# Altium schematic sheet Main.SchDoc
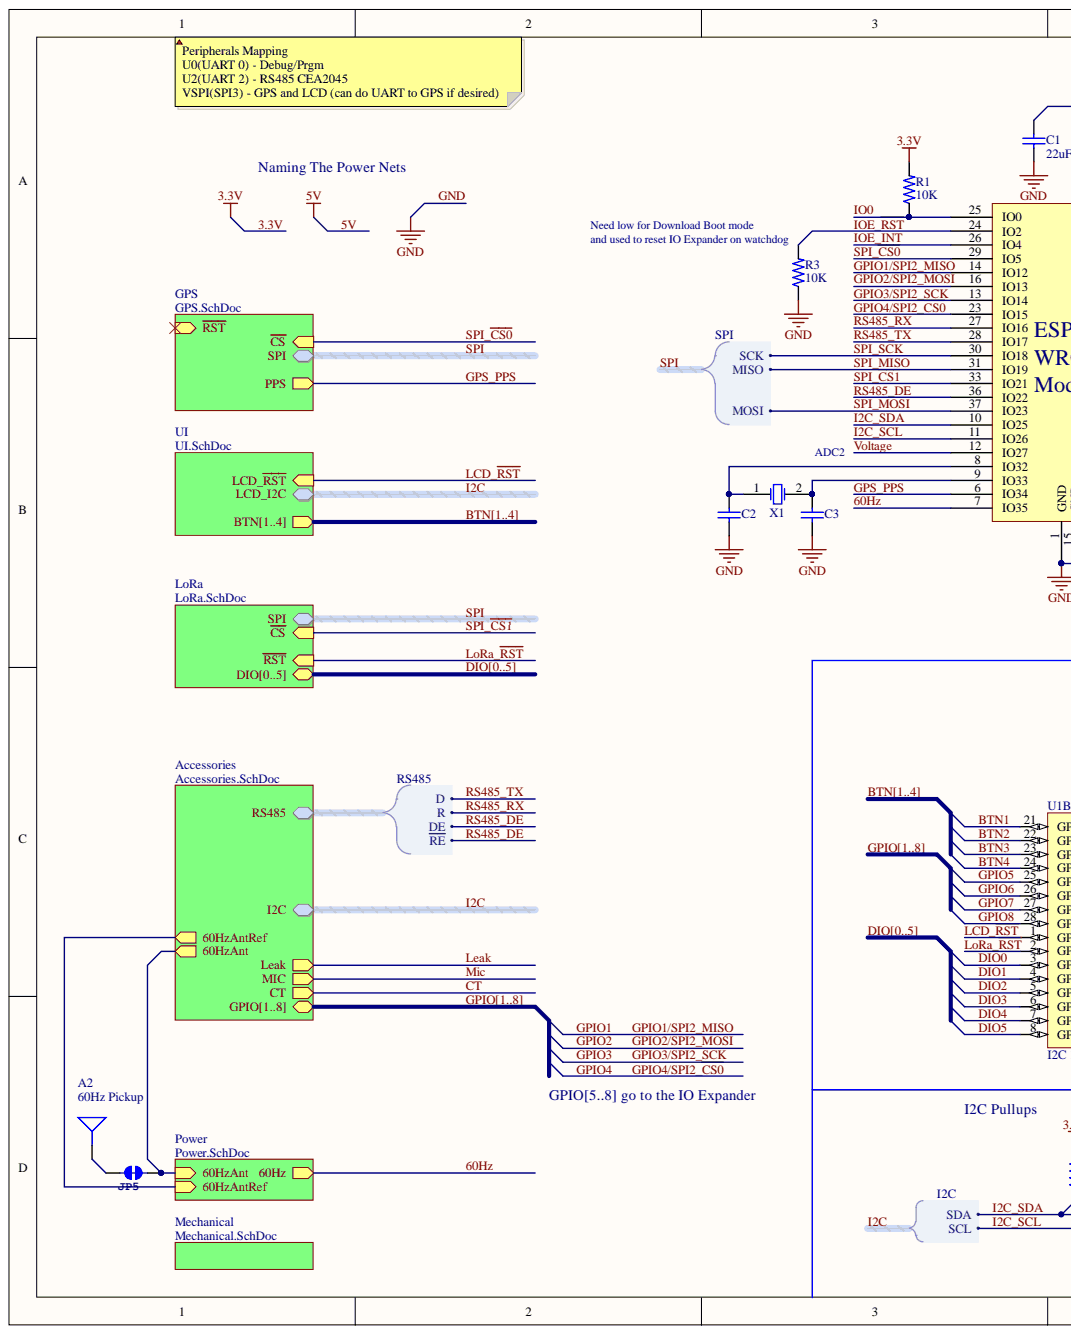
[diagram p0: Main.SchDoc, left]
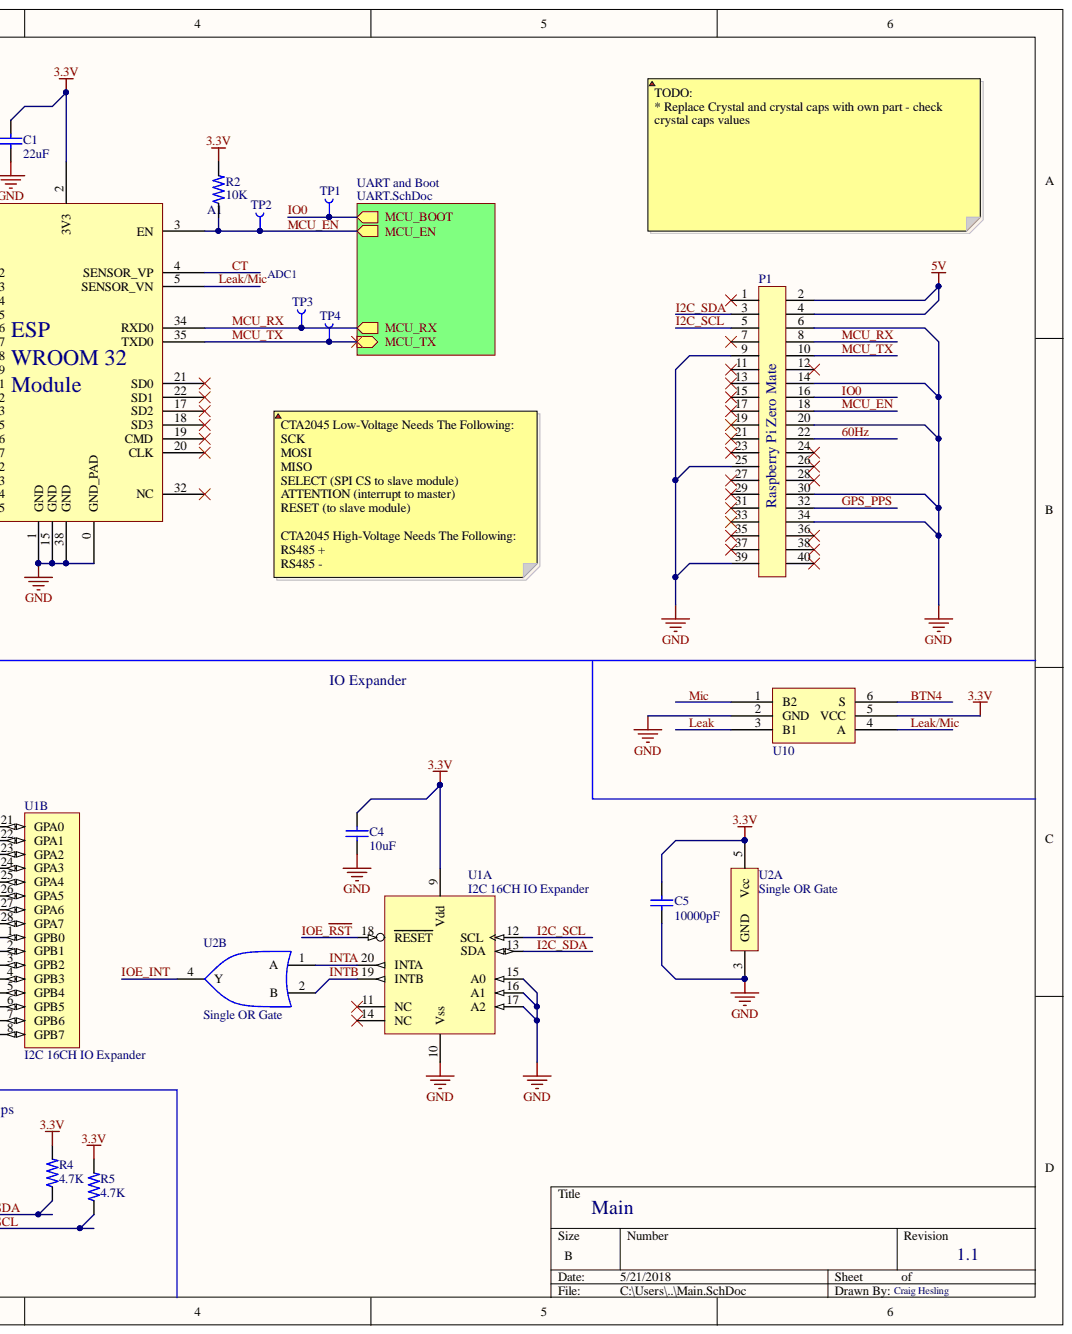
[diagram p1: Main.SchDoc, right]

## Parts
A1  ESP-WROOM-32  [ESP-WROOM-32]  (value not drawn)
A2  60Hz Pickup  [60HzPickup]
C1  22uF  [CAPC2013X14L - CAP0805 1.45mm Tall]
C2  CAP 12pF 16V 0603(1608)  [CAPC0603(1608)100_M]  (value not drawn)
C3  CAP 12pF 16V 0603(1608)  [CAPC0603(1608)100_M]  (value not drawn)
C4  10uF  [CAPC2013X14L - CAP0805 1.45mm Tall]
C5  10000pF  [CAPC1608X09L - CAP0603]
JP5  JUMPER-PAD-2-NC_BY_TRACENO_SILK  [PAD-JUMPER-2-NC_BY_TRACE_NO_SILK]  (value not drawn)
P1  Raspbery Pi Zero Mate  [Raspberry Pi Zero]  (value not drawn)
R1  10K  [RESC1608X06L - RES0603]
R2  10K  [RESC1608X06L - RES0603]
R3  10K  [RESC1608X06L - RES0603]
R4  4.7K  [RESC1608X06L - RES0603]
R5  4.7K  [RESC1608X06L - RES0603]
TP1  Test Point  [TestPoint1]  (value not drawn)
TP2  Test Point  [TestPoint1]  (value not drawn)
TP3  Test Point  [TestPoint1]  (value not drawn)
TP4  Test Point  [TestPoint1]  (value not drawn)
U1  I2C 16CH IO Expander  [SOIC127P1030X265-28L]  (parts A,B)
U2  Single OR Gate  [SOT95P280X140-5L]  (parts A,B)
U10  SN74LVC1G3157DCKR  [SC-70-6  (SOT-363)]  (value not drawn)
X1  14340272-32.768KHz  [ITTI-14340272-32.768KHz-2_V]  (value not drawn)

## Nets
3.3V: A1.2(3V3) C1.2 C4.2 C5.2 R1.2 R2.2 R4.2 R5.2 U1.9(Vdd) U2.5(Vcc) U10.5(VCC)
5V: P1.2 P1.4
60Hz: A1.7(IO35) P1.22
BTN1: U1.21(GPA0)  [also labelled BTN]
BTN2: U1.22(GPA1)  [also labelled BTN]
BTN3: U1.23(GPA2)  [also labelled BTN]
BTN4: U1.24(GPA3) U10.6(S)  [also labelled BTN]
CT: A1.4(SENSOR_VP)
DIO0: U1.3(GPB2)  [also labelled DIO]
DIO1: U1.4(GPB3)  [also labelled DIO]
DIO2: U1.5(GPB4)  [also labelled DIO]
DIO3: U1.6(GPB5)  [also labelled DIO]
DIO4: U1.7(GPB6)  [also labelled DIO]
DIO5: U1.8(GPB7)  [also labelled DIO]
GND: A1.0(GND_PAD) A1.1(GND) A1.15(GND) A1.38(GND) C1.1 C2.1 C3.1 C4.1 C5.1 P1.6 P1.9 P1.14 P1.20 P1.25 P1.30 P1.34 P1.39 R3.1 U1.10(Vss) U1.15(A0) U1.16(A1) U1.17(A2) U2.3(GND) U10.2(GND)
GPIO1: A1.14(IO12)  [also labelled GPIO]
GPIO2: A1.16(IO13)  [also labelled GPIO]
GPIO3: A1.13(IO14)  [also labelled GPIO]
GPIO4: A1.23(IO15)  [also labelled GPIO]
GPIO5: U1.25(GPA4)  [also labelled GPIO]
GPIO6: U1.26(GPA5)  [also labelled GPIO]
GPIO7: U1.27(GPA6)  [also labelled GPIO]
GPIO8: U1.28(GPA7)  [also labelled GPIO]
GPS_PPS: A1.6(IO34) P1.32
I2C.SCL: A1.11(IO26) P1.5 R5.1 U1.12(SCL)
I2C.SDA: A1.10(IO25) P1.3 R4.1 U1.13(SDA)
INTA: U1.20(INTA) U2.1(A)
INTB: U1.19(INTB) U2.2(B)
IO0: A1.25(IO0) P1.16 R1.1 TP1.1
IOE_INT: A1.26(IO4) U2.4(Y)
IOE_~{RST}: A1.24(IO2) R3.2 U1.18(RESET)
LCD_~{RST}: U1.1(GPB0)
Leak: U10.3(B1)
Leak/Mic: A1.5(SENSOR_VN) U10.4(A)
LoRa_~{RST}: U1.2(GPB1)
MCU_EN: A1.3(EN) P1.18 R2.1 TP2.1
MCU_RX: A1.34(RXD0) P1.8 TP3.1
MCU_TX: A1.35(TXD0) P1.10 TP4.1
Mic: U10.1(B2)
RS485_DE: A1.36(IO22)
RS485_RX: A1.27(IO16)
RS485_TX: A1.28(IO17)
SPI.MISO: A1.31(IO19)
SPI.MOSI: A1.37(IO23)
SPI.SCK: A1.30(IO18)
SPI_~{CS0}: A1.29(IO5)
SPI_~{CS1}: A1.33(IO21)
Voltage: A1.12(IO27)
NetA1_8: A1.8(IO32) C2.2 X1.1
NetA1_9: A1.9(IO33) C3.2 X1.2
NetA1_17: A1.17(SD2)
NetA1_18: A1.18(SD3)
NetA1_19: A1.19(CMD)
NetA1_20: A1.20(CLK)
NetA1_21: A1.21(SD0)
NetA1_22: A1.22(SD1)
NetA1_32: A1.32(NC)
NetA2_1: A2.1 JP5.1
NetJP5_2: JP5.2
NetP1_1: P1.1
NetP1_7: P1.7
NetP1_11: P1.11
NetP1_12: P1.12
NetP1_13: P1.13
NetP1_15: P1.15
NetP1_17: P1.17
NetP1_19: P1.19
NetP1_21: P1.21
NetP1_23: P1.23
NetP1_24: P1.24
NetP1_26: P1.26
NetP1_27: P1.27
NetP1_28: P1.28
NetP1_29: P1.29
NetP1_31: P1.31
NetP1_33: P1.33
NetP1_35: P1.35
NetP1_36: P1.36
NetP1_37: P1.37
NetP1_38: P1.38
NetP1_40: P1.40
NetU1_11: U1.11(NC)
NetU1_14: U1.14(NC)
60HzAntRef:
~{RST}:

## Library data of the parts
A1 = ESP-WROOM-32: ESP WROOM 32 Module; Component Kind: Standard; Library Name: Modules.SchLib; Supplier 1: Digi-Key; Supplier Part Number 1: 1528-1801-ND
A2 = 60Hz pickup with via: 5cm 60Hz pickup antenna with via for external wire; Component Kind: Standard; Library Name: Antennas.SchLib
C1 = CAP0805 22uF 10% 6.3V X5R: SMT 0805 Ceramic Capacitor; TempCoeff: X5R; Tolerance: 10%; Voltage: 6.3V; Component Kind: Standard; Library Name: Passives.SchLib; Supplier 1: Digi-Key; Supplier 2: Digi-Key; Supplier Part Number 1: 1276-6687-1-ND; Supplier Part Number 2: 311-1860-1-ND; Value: 22uF
C2 C3 = CMP-1035-00607-1: CAP 12pF 16V ±10% 0603 (1608 Metric) Thickness 1mm SMD; Case-EIA: 0603; Case-Metric: 1608; Tolerance: Â±10%; Component Kind: Standard; Library Name: Altium Content Vault; Max Thickness: 1 mm; Rated Voltage: 16 V; Technology: SMT; Value: 12pF
C4 = CAP0805 10uF 10% 6.3V X5R: SMT 0805 Ceramic Capacitor; TempCoeff: X5R; Tolerance: 10%; Voltage: 6.3V; Component Kind: Standard; Library Name: Passives.SchLib; Supplier 1: Digi-Key; Supplier Part Number 1: 1276-6457-1-ND; Value: 10uF
C5 = CAP0603 10000pF 10% 50V X7R: SMT 0603 Ceramic Capacitor; TempCoeff: X7R; Tolerance: 10%; Voltage: 50V; Component Kind: Standard; Library Name: Passives.SchLib; Supplier 1: Digi-Key; Supplier 2: Digi-Key; Supplier 3: Digi-Key; Supplier Part Number 1: 478-1215-1-ND; Supplier Part Number 2: 311-1080-1-ND; Supplier Part Number 3: 490-1494-1-ND; Value: 10000pF
P1 = Raspberry Pi Zero Mate: The header and mechanical drawings for connnecting a Raspbery Pi Zero; Component Kind: Standard; Library Name: Misc.SchLib
R1-R3 = RES0603 10K 1% 1/10W: SMT 0603 Ceramic Resistor; Power: 1/10W; Tolerance: 1%; Component Kind: Standard; Library Name: Passives.SchLib; Supplier 1: Digi-Key; Supplier 2: Digi-Key; Supplier 3: Digi-Key; Supplier Part Number 1: 311-10.0KHRCT-ND; Supplier Part Number 2: RMCF0603FT10K0CT-ND; Supplier Part Number 3: P10.0KHCT-ND; Value: 10K
R4 R5 = RES0603 4.7K 1% 1/10W: SMT 0603 Ceramic Resistor; Power: 1/10W; Tolerance: 1%; Component Kind: Standard; Library Name: Passives.SchLib; Supplier 1: Digi-Key; Supplier 2: Digi-Key; Supplier 3: Digi-Key; Supplier Part Number 1: 311-4.70KHRCT-ND; Supplier Part Number 2: P4.70KHCT-ND; Supplier Part Number 3: RMCF0603FT4K70CT-ND; Value: 4.7K
TP1-TP4 = TestPoint1: Small 0.762mm Round Test Point; Component Kind: Standard; Library Name: Passives.SchLib
U1 = MCP23017T-E/SO: Microchip MCP23017 I2C 16CH IO Expander; Component Kind: Standard; Supplier 1: Digi-Key; Supplier Part Number 1: MCP23017T-E/SOCT-ND; Library Name: Actives.SchLib
U2 = NC7S32M5X: Fairchild/ON Semi NC7S32M5X Single OR Gate; Component Kind: Standard; Supplier 1: Digi-Key; Supplier Part Number 1: NC7S32M5XCT-ND; Library Name: Actives.SchLib
U10 = SN74LVC1G3157DCKR: IC, SWITCH, SPDT ANA, SMD; Manufacturer: Texas Instruments; Bandwidth: 300MHz; High Level Output Current: 128mA; Max Supply Current: 10uA; Max Supply Voltage: 5.5V; Min Supply Voltage: 1.65V; Number of Bits: 1; Number of Outputs: 2; On-State Resistance: 15R; Operating Supply Current: 1uA; Polarity: Non-Inverting; Propagation Delay: 300ps; Supply Type: Single; Turn-Off Delay Time: 3.8ns; Turn-On Delay Time: 5.7ns; Case/Package: SC; Component Kind: Standard; ComponentLink1Description: https://datasheet.ciiva.com/1669/sn74lvc1g3157-1669380.pdf; ComponentLink1URL: https://datasheet.ciiva.com/1669/sn74lvc1g3157-1669380.pdf; ComponentLink2Description: https://datasheet.ciiva.com/1514/1857156-1514549.pdf; ComponentLink2URL: https://datasheet.ciiva.com/1514/1857156-1514549.pdf; ComponentLink3Description: https://datasheet.ciiva.com/1669/sn74lvc1g3157-1669381.pdf; ComponentLink3URL: https://datasheet.ciiva.com/1669/sn74lvc1g3157-1669381.pdf; ComponentLink4Description: https://datasheet.ciiva.com/14435/sn74lvc1g3157-14435733.pdf; ComponentLink4URL: https://datasheet.ciiva.com/14435/sn74lvc1g3157-14435733.pdf; ComponentLink5Description: https://datasheet.ciiva.com/34301/756764-34301477.pdf; ComponentLink5URL: https://datasheet.ciiva.com/34301/756764-34301477.pdf; ComponentLink6Description: https://datasheet.ciiva.com/1514/1857156-1514550.pdf; ComponentLink6URL: https://datasheet.ciiva.com/1514/1857156-1514550.pdf; ComponentLink7Description: https://datasheet.ciiva.com/1669/sn74lvc1g3157-1669382.pdf; ComponentLink7URL: https://datasheet.ciiva.com/1669/sn74lvc1g3157-1669382.pdf; ComponentLink8Description: https://datasheet.ciiva.com/46411/1786793-46411528.pdf; ComponentLink8URL: https://datasheet.ciiva.com/46411/1786793-46411528.pdf; ComponentLink9Description: https://datasheet.ciiva.com/16539/sn74lvc1g3157-16539371.pdf; ComponentLink9URL: https://datasheet.ciiva.com/16539/sn74lvc1g3157-16539371.pdf; ComponentLink10Description: https://datasheet.ciiva.com/15127/getdatasheetpartid-187022-15127388.pdf; ComponentLink10URL: https://datasheet.ciiva.com/15127/getdatasheetpartid-187022-15127388.pdf; ComponentLink11Description: https://datasheet.ciiva.com/41461/001187224-da-01-en-ic-switch-sn74lvc1g3157dckr-sc-70-6-tid-41461882.pdf; ComponentLink11URL: https://datasheet.ciiva.com/41461/001187224-da-01-en-ic-switch-sn74lvc1g3157dckr-sc-70-6-tid-41461882.pdf; Contact Plating: Gold; Height: 0.9mm; Lead Free: Lead Free; Length: 2mm; Low Level Output Current: 128mA; Manufacturer Part Number: SN74LVC1G3157DCKR; Max Operating Temperature: 125°C; Min Operating Temperature: -40°C; Mount: Surface Mount; Nominal Supply Current: 10uA; Number of Channels: 1; Number of Inputs: 1; Number of Pins: 6; Package Quantity: 3000; Packaging: Tape and Reel; Pricing 1: 1=0.29612, 30=0.2755, 75=0.21727, 150=0.1881, 375=0.15656, 3000=0.07742, 6000=0.07106, 12000=0.07058, 18000=0.07011, 30000=0.05947 (USD); Radiation Hardening: No; REACH SVHC: No SVHC; Stock 1: 76000; Supplier 1: Avnet; Supplier Part Number 1: SN74LVC1G3157DCKR/BKN; Termination: SMD/SMT; Throw Configuration: SPDT; Weight: 8.8E-05oz; Width: 1.25mm; Library Name: Actives.SchLib
X1 = CMP-0463-00001-2: Crystal, 32.768 KHz, -40 to 85 degC, 3.2 x 1.5 x 0.9 mm SMD; Manufacturer: ITTI; Drive Level: 1 max. uw; Frequency Tolerance: +/-20 ppm; Load Capacitance: 12.5 pF; Component Kind: Standard; ComponentLink1Description: Manufacturer URL; ComponentLink1URL: http://www.itti-co.com; Library Name: Altium Content Vault; Mounting Technology: Surface Mount; Nominal Frequency: 32.768KHz; Operating temperature: -40 to 85 degC; PackageDescription: 2-Pin Hand Drawn, Body 3.2 x 1.5mm, Pitch 2.5 mm; PackageReference: 14340272-32.768KHz-2; PartNumber: 14340272-32.768KHz
JP5 = JUMPER-PAD-2-NC_BY_TRACENO_SILK: Component Kind: Standard; Library Name: Passives.SchLib
